# Altium schematic sheet Connectors.SchDoc
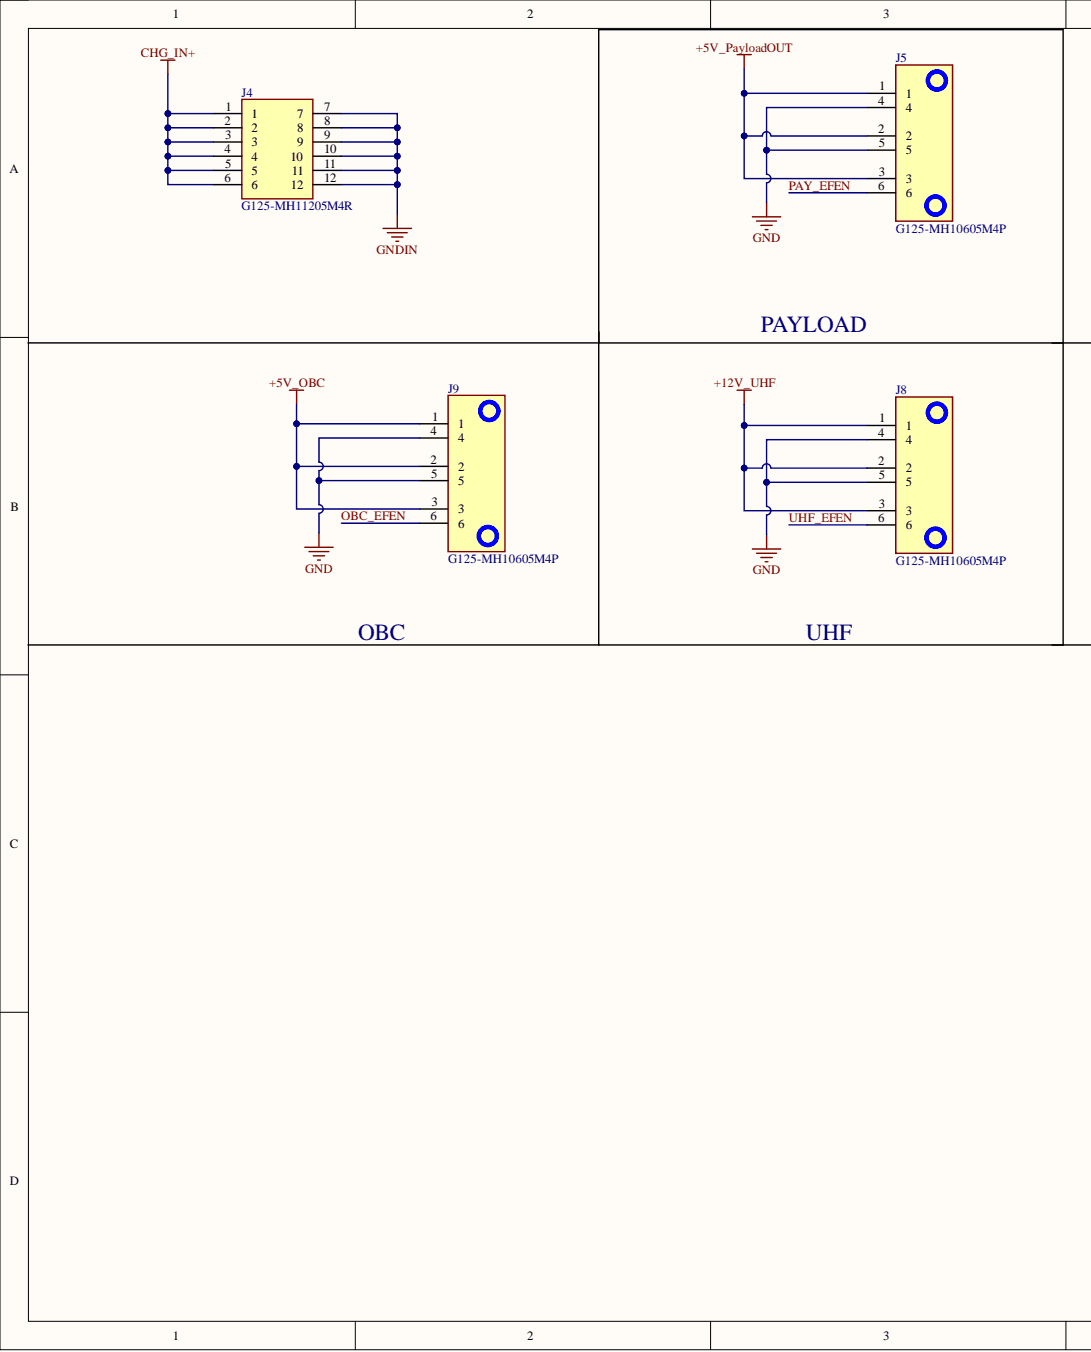
[diagram p0: Connectors.SchDoc, left]
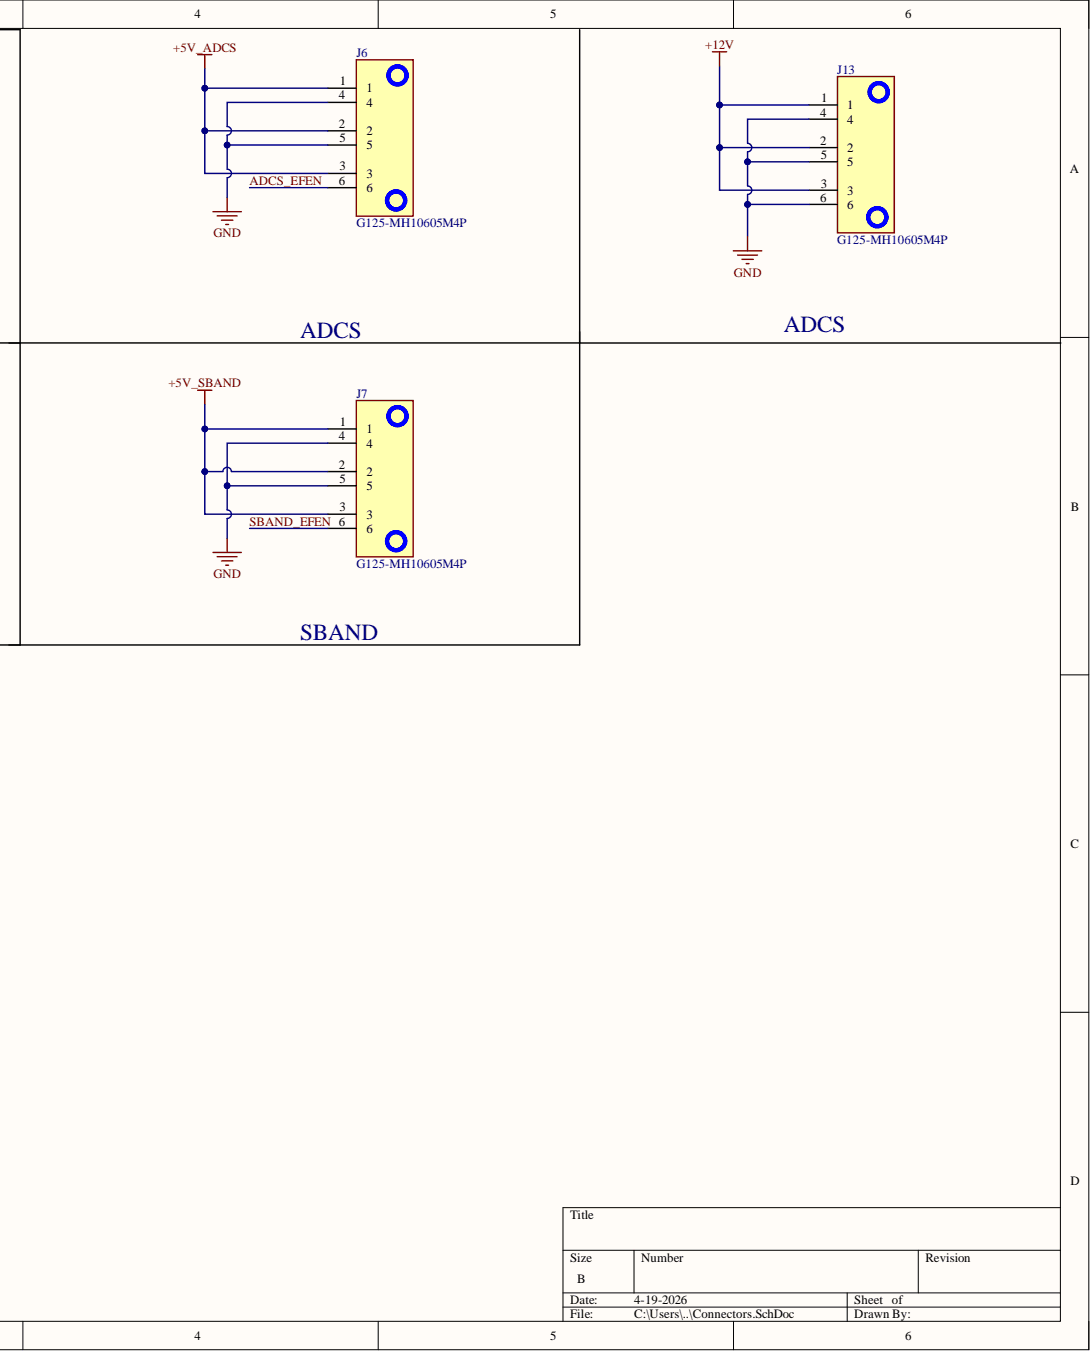
[diagram p1: Connectors.SchDoc, right]

## Parts
J4  G125-MH11205M4R  [G125-MH11205M4R]
J5  G125-MH10605M4P  [G125-MH10605M4P_1]
J6  G125-MH10605M4P  [G125-MH10605M4P_1]
J7  G125-MH10605M4P  [G125-MH10605M4P_1]
J8  G125-MH10605M4P  [G125-MH10605M4P_1]
J9  G125-MH10605M4P  [G125-MH10605M4P_1]
J13  G125-MH10605M4P  [G125-MH10605M4P_1]

## Nets
+5V_ADCS: J6.1 J6.2 J6.3
+5V_OBC: J9.1 J9.2 J9.3
+5V_PayloadOUT: J5.1 J5.2 J5.3
+5V_SBAND: J7.1 J7.2 J7.3
+12V: J13.1 J13.2 J13.3
+12V_UHF: J8.1 J8.2 J8.3
CHG_IN+: J4.1 J4.2 J4.3 J4.4 J4.5 J4.6
GND: J5.4 J5.5 J6.4 J6.5 J7.4 J7.5 J8.4 J8.5 J9.4 J9.5 J13.4 J13.5 J13.6
GNDIN: J4.7 J4.8 J4.9 J4.10 J4.11 J4.12
ADCS_EFEN: J6.6
OBC_EFEN: J9.6
PAY_EFEN: J5.6
SBAND_EFEN: J7.6
UHF_EFEN: J8.6

## Library data of the parts
J4 = G125-MH11205M4R: Connector; Manufacturer_Name: Harwin; Component Kind: Standard; Datasheet Link: https://content.harwin.com/m/dde372ac2f9348fc/original/DRG-02922-Technical-Drawing-Datasheet-G125-MH1XX05M4R-pdf.pdf; Height: 5.3mm; Library Name: SamacSys.SchLib; Manufacturer_Part_Number: G125-MH11205M4R; Mouser Part Number: 855-G125MH1125M4RM4R; Mouser Price/Stock: https://www.mouser.co.uk/ProductDetail/Harwin/G125-MH11205M4R?qs=vHuUswq2%252BsxbzvcAt9CyPA%3D%3D
J5-J9 J13 = G125-MH10605M4P: Connector; Manufacturer_Name: Harwin; Component Kind: Standard; Datasheet Link: https://content.harwin.com/m/667f1be9427fb768/original/DRG-02921-Technical-Drawing-Datasheet-G125-MH1XX05M4P-pdf.pdf; Height: 5.3mm; Manufacturer_Part_Number: G125-MH10605M4P; Mouser Part Number: 855-G125MH1065M4PM4P; Mouser Price/Stock: https://www.mouser.co.uk/ProductDetail/Harwin/G125-MH10605M4P?qs=vHuUswq2%252BswF07IXFR1W6A%3D%3D; Library Name: STeRG-Passives-Standard.SchLib
